# Altium schematic sheet haptic_module.SchDoc
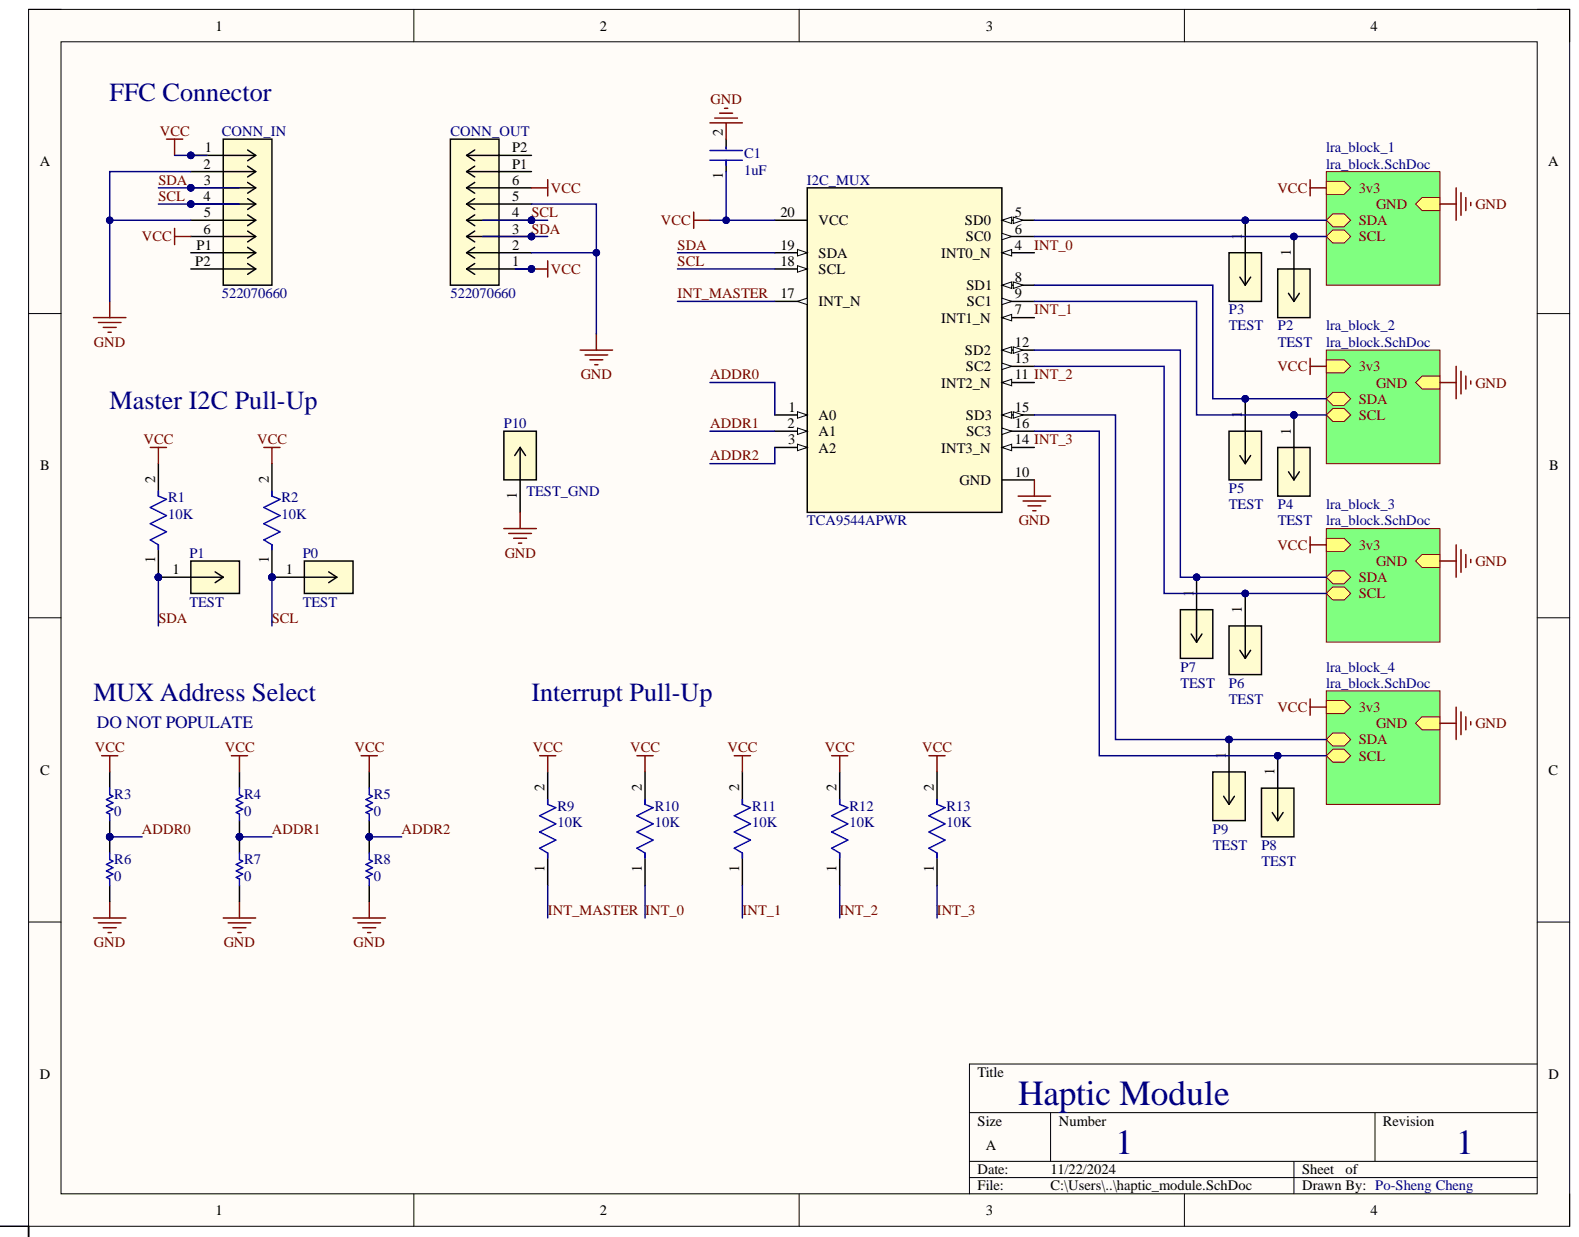
[diagram p0: haptic_module.SchDoc]

## Parts
C1  1uF  [FP-CC0805-0_85-MFG]
CONN_IN  522070660  [CON_522070660_MOL]
CONN_OUT  522070660  [CON_522070660_MOL]
I2C_MUX  TCA9544APWR  [PW0020A_N]
P0  TEST  [CON1_1X1_TU_HTS_SAI]
P1  TEST  [CON1_1X1_TU_HTS_SAI]
P2  TEST  [CON1_1X1_TU_HTS_SAI]
P3  TEST  [CON1_1X1_TU_HTS_SAI]
P4  TEST  [CON1_1X1_TU_HTS_SAI]
P5  TEST  [CON1_1X1_TU_HTS_SAI]
P6  TEST  [CON1_1X1_TU_HTS_SAI]
P7  TEST  [CON1_1X1_TU_HTS_SAI]
P8  TEST  [CON1_1X1_TU_HTS_SAI]
P9  TEST  [CON1_1X1_TU_HTS_SAI]
P10  TEST_GND  [CON1_1X1_TU_HTS_SAI]
R1  10K  [FP-RT0603-MFG]
R2  10K  [FP-RT0603-MFG]
R3  0  [RESC1608X55X25ML10T15]
R4  0  [RESC1608X55X25ML10T15]
R5  0  [RESC1608X55X25ML10T15]
R6  0  [RESC1608X55X25ML10T15]
R7  0  [RESC1608X55X25ML10T15]
R8  0  [RESC1608X55X25ML10T15]
R9  10K  [FP-RT0603-MFG]
R10  10K  [FP-RT0603-MFG]
R11  10K  [FP-RT0603-MFG]
R12  10K  [FP-RT0603-MFG]
R13  10K  [FP-RT0603-MFG]

## Nets
GND: C1.2 CONN_IN.2 CONN_IN.5 CONN_OUT.2 CONN_OUT.5 I2C_MUX.10(GND) P10.1 R6.1 R7.1 R8.1
VCC: C1.1 CONN_IN.1 CONN_IN.6 CONN_OUT.1 CONN_OUT.6 I2C_MUX.20(VCC) R1.2 R2.2 R3.2 R4.2 R5.2 R9.2 R10.2 R11.2 R12.2 R13.2
ADDR0: I2C_MUX.1(A0) R3.1 R6.2
ADDR1: I2C_MUX.2(A1) R4.1 R7.2
ADDR2: I2C_MUX.3(A2) R5.1 R8.2
INT_0: I2C_MUX.4(INT0_N) R10.1
INT_1: I2C_MUX.7(INT1_N) R11.1
INT_2: I2C_MUX.11(INT2_N) R12.1
INT_3: I2C_MUX.14(INT3_N) R13.1
INT_MASTER: I2C_MUX.17(INT_N) R9.1
SCL: CONN_IN.4 CONN_OUT.4 I2C_MUX.18(SCL) P0.1 R2.1
SDA: CONN_IN.3 CONN_OUT.3 I2C_MUX.19(SDA) P1.1 R1.1
NetCONN_IN_P1: CONN_IN.P1
NetCONN_IN_P2: CONN_IN.P2
NetCONN_OUT_P1: CONN_OUT.P1
NetCONN_OUT_P2: CONN_OUT.P2
NetI2C_MUX_5: I2C_MUX.5(SD0) P3.1
NetI2C_MUX_6: I2C_MUX.6(SC0) P2.1
NetI2C_MUX_8: I2C_MUX.8(SD1) P5.1
NetI2C_MUX_9: I2C_MUX.9(SC1) P4.1
NetI2C_MUX_12: I2C_MUX.12(SD2) P7.1
NetI2C_MUX_13: I2C_MUX.13(SC2) P6.1
NetI2C_MUX_15: I2C_MUX.15(SD3) P9.1
NetI2C_MUX_16: I2C_MUX.16(SC3) P8.1

## Library data of the parts
C1 = CMP-03422-000001-1: CAP CER 1UF 16V X7R 0805; Manufacturer: Yageo; Manufacturer Part Number: CC0805KKX7R7BB105; Material: Ceramic; Tolerance: 0.1; Automotive: No; Capacitance: 1 uF; Category: Cap; Component Kind: Standard; ComponentLink2Description: Datasheet; ComponentLink2URL: http://www.yageo.com/documents/recent/UPY-GPHC_Y5V_6.3V-to-50V_8.pdf; Device Class L1: Passive Components; Device Class L2: Capacitors; Device Class L3: Ceramic Capacitors; Digikey Description: CAP CER 1UF 16V X7R 0805; Footprint Url: http://www.yageo.com/exep/pages/download/literatures/UPY-C_GEN_24.pdf; Height: 0.95mm; Ipc Land Pattern Name: CAPC200125X85; Lead Free: Yes; Library Name: Altium Content Vault; Mouser Description: Multilayer Ceramic Capacitors MLCC - SMD/SMT 1.0uF 16V X7R 10%; Octopart: https://octopart.com/cc0805kkx7r7bb105-yageo-8376555; Package: CC0805-0.85; RoHS: TRUE; Temperature: -30°C to +85°C; Temperature Characteristic: X7R; Temperature Range High: +85°C; Temperature Range Low: -30°C; Value: 1 uF; Voltage Rating: 16 V
CONN_IN CONN_OUT = 522070660: No Description Available; Mfr_Name: Molex Connector Corporation; Component Kind: Standard; Library Name: 522070660.SchLib; RefDes: RefDes; Type: Type
I2C_MUX = TCA9544APWR: No Description Available; Manufacturer: Texas Instruments; CompType: IC; Component Kind: Standard; Library Name: TCA9544APWR.SchLib; Mfr_Name: Texas Instruments; MountType: SMT; PackageReference: PW0020A; PartNumber: TCA9544APWR; RefDes: RefDes; RoHS: Y; SymbolVerison: 2015-05-04; TYPE: TYPE
P0-P10 = TS-101-T-A: No Description Available; Mfr_Name: Samtec Inc; Component Kind: Standard; Library Name: TS-101-T-A.SchLib; RefDes: RefDes; Type: Type
R1 R2 R9-R13 = CMP-2000-04517-2: RES SMD 10K OHM 0.1% 1/10W 0603; Manufacturer: Yageo; Manufacturer Part Number: RT0603BRE0710KL; Power Rating: 0.1W; Resistance: 10KΩ; Temperature Coefficient: 50 ppm/°C; Tolerance: 0.001; Value: 10KΩ; Voltage Rating: 75V; Automotive: No; Category: Res; Component Kind: Standard; ComponentLink2Description: Datasheet; ComponentLink2URL: http://www.yageo.com/documents/recent/PYu-RT_1-to-0.01_RoHS_L_9.pdf; Composition: Thin Film; Device Class L1: Passive Components; Device Class L2: Resistors; Device Class L3: Chip SMD Resistors; Digikey Description: RES SMD 10K OHM 0.1% 1/10W 0603; Footprint Url: http://www.yageo.com/exep/pages/download/literatures/PYu-R_Mount_10.pdf; Height: 0.55mm; Ipc Land Pattern Name: RESC16080X45; Lead Free: Yes; Library Name: Altium Content Vault; Mouser Description: Res Thin Film 0603 10K Ohm 0.1% 1/10W ±50ppm/°C Molded SMD SMD Paper T/R; Octopart: https://octopart.com/rt0603bre0710kl-yageo-1273959; Package: RT0603; RoHS: TRUE; Series: RT; Temperature: -55°C to +155°C; Temperature Range High: +155°C; Temperature Range Low: -55°C
R3-R8 = CMP-2100-03667-1: Chip Resistor, 0 Ohm, +/- 5%, 0.1 W, -55 to 155 degC, 0603 (1608 Metric), RoHS, Tape and Reel; Manufacturer: Yageo; Power Rating: 100mW; Resistance: 0mOhm; Temperature Coefficient: 200ppm/degreesC; Voltage Rating: 50V; Case Code (Imperial): 0603; Case Code (Metric): 1608; Case/Package: 0603; Component Kind: Standard; Composition: ThickFilm; Contact Plating: Tin; Datasheet URL: http://www.yageo.com/NewPortal/yageodocoutput?fileName=/pdf/R-Chip/PYu-RC_Group_51_RoHS_L_5.pdf; Datasheet Version: Rev. 5, 10/2016; Depth: 0.8mm; Height: 0.45mm; Lead Free: LeadFree; Length: 1.6mm; Library Name: Altium Content Vault; Manufacturer URL: http://www.yageo.com/; Max Operating Temperature: 155degC; Military Standard: Not; Min Operating Temperature: -55degC; Number of Pins: 2; Package Description: 2-Pin Surface Mount Device, Body 1.6 x 0.8 mm; Package Quantity: 5000; Package Reference: 0603; Packaging: TapeandReel; Radiation Hardening: No; REACH SVHC: NoSVHC; RoHSCompliant: true; Termination: SMD/SMT; Tolerance: 5%; Voltage Rating (DC): 50V; Width: 0.8mm
